# Altium schematic sheet pca10036_sheet3_buttons_and_leds.SchDoc
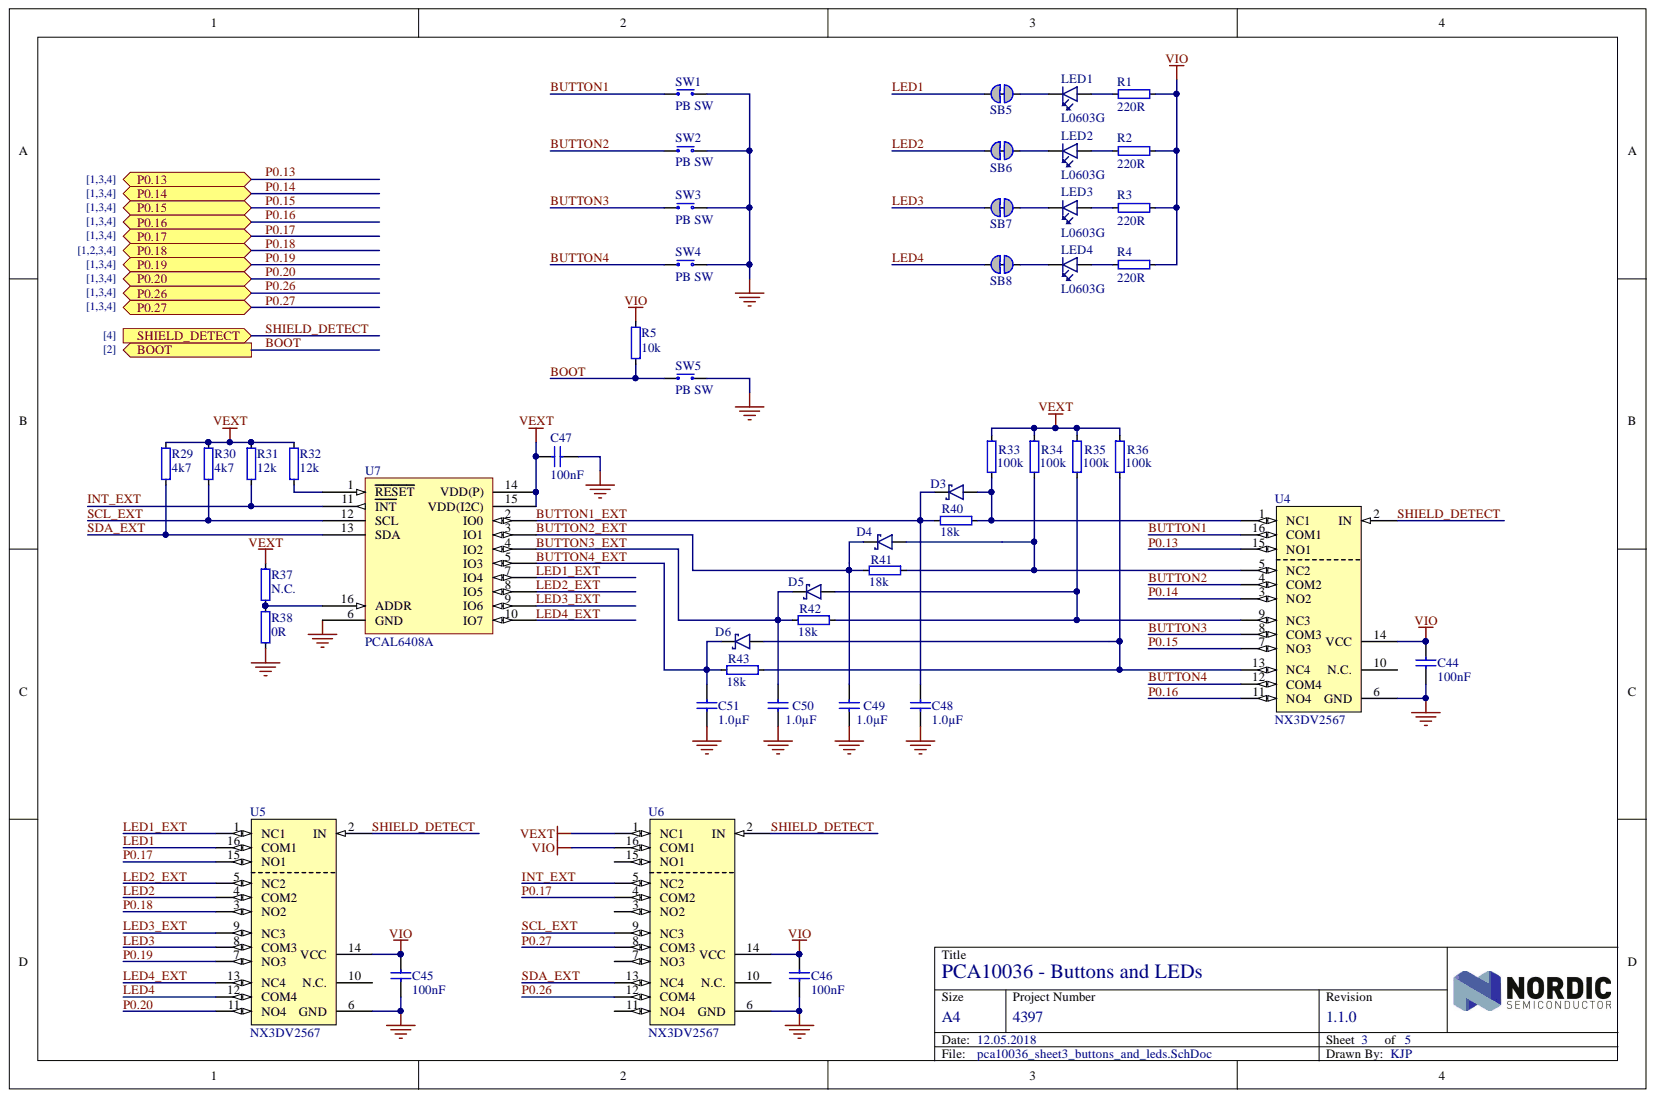
[diagram p0: pca10036_sheet3_buttons_and_leds.SchDoc]

## Parts
C44  100nF  [CAPC1005X04L]
C45  100nF  [CAPC1005X04L]
C46  100nF  [CAPC1005X04L]
C47  100nF  [CAPC1005X04L]
C48  1.0µF  [CAPC1005X04L]
C49  1.0µF  [CAPC1005X04L]
C50  1.0µF  [CAPC1005X04L]
C51  1.0µF  [CAPC1005X04L]
D3  1PS79SB30.115  [SOD-523]  (value not drawn)
D4  1PS79SB30.115  [SOD-523]  (value not drawn)
D5  1PS79SB30.115  [SOD-523]  (value not drawn)
D6  1PS79SB30.115  [SOD-523]  (value not drawn)
LED1  L0603G  [LED_0603_G]
LED2  L0603G  [LED_0603_G]
LED3  L0603G  [LED_0603_G]
LED4  L0603G  [LED_0603_G]
R1  220R  [RESC1005X04L]
R2  220R  [RESC1005X04L]
R3  220R  [RESC1005X04L]
R4  220R  [RESC1005X04L]
R5  10k  [RESC1005X04L]
R29  4k7  [RESC1005X04L]
R30  4k7  [RESC1005X04L]
R31  12k  [RESC1005X04L]
R32  12k  [RESC1005X04L]
R33  100k  [RESC1005X04L]
R34  100k  [RESC1005X04L]
R35  100k  [RESC1005X04L]
R36  100k  [RESC1005X04L]
R37  N.C.  [RESC1005X04L]
R38  0R  [RESC1005X04L]
R40  18k  [RESC1005X04L]
R41  18k  [RESC1005X04L]
R42  18k  [RESC1005X04L]
R43  18k  [RESC1005X04L]
SB5  Solderbridge  [Solderbridge_Shorted]  (value not drawn)
SB6  Solderbridge  [Solderbridge_Shorted]  (value not drawn)
SB7  Solderbridge  [Solderbridge_Shorted]  (value not drawn)
SB8  Solderbridge  [Solderbridge_Shorted]  (value not drawn)
SW1  PB SW  [ALPS_SKHUxxx010_WO_GND]
SW2  PB SW  [ALPS_SKHUxxx010_WO_GND]
SW3  PB SW  [ALPS_SKHUxxx010_WO_GND]
SW4  PB SW  [ALPS_SKHUxxx010_WO_GND]
SW5  PB SW  [ALPS_SKHUxxx010_WO_GND]
U4  NX3DV2567  [XQFN40P180X260X50-16N]
U5  NX3DV2567  [XQFN40P180X260X50-16N]
U6  NX3DV2567  [XQFN40P180X260X50-16N]
U7  PCAL6408A  [XQFN40P180X260X50-16N]

## Ports
BOOT, P0.13, P0.14, P0.15, P0.16, P0.17, P0.18, P0.19, P0.20, P0.26, P0.27, SHIELD_DETECT

## Nets
GND: C44.1 C45.1 C46.1 C47.1 C48.2 C49.1 C50.1 C51.2 R38.1 SW1.2 SW2.2 SW3.2 SW4.2 SW5.2 U4.6(GND) U5.6(GND) U6.6(GND) U7.6(GND)
VEXT: C47.2 R29.2 R30.2 R31.2 R32.2 R33.2 R34.2 R35.2 R36.2 R37.2 U6.1(NC1) U7.14(VDD(P)) U7.15(VDD(I2C))
VIO: C44.2 C45.2 C46.2 R1.2 R2.2 R3.2 R4.2 R5.2 U4.14(VCC) U5.14(VCC) U6.14(VCC) U6.16(COM1)
BOOT: R5.1 SW5.1 ; port BOOT
BUTTON1: SW1.1 U4.16(COM1)
BUTTON1_EXT: C48.1 D3.3 R40.1 U7.2(IO0)
BUTTON2: SW2.1 U4.4(COM2)
BUTTON2_EXT: C49.2 D4.3 R41.1 U7.3(IO1)
BUTTON3: SW3.1 U4.8(COM3)
BUTTON3_EXT: C50.2 D5.3 R42.1 U7.4(IO2)
BUTTON4: SW4.1 U4.12(COM4)
BUTTON4_EXT: C51.1 D6.3 R43.1 U7.5(IO3)
INT_EXT: R31.1 U6.5(NC2) U7.11(INT)
LED1: SB5.1 U5.16(COM1)
LED1_EXT: U5.1(NC1) U7.7(IO4)
LED2: SB6.1 U5.4(COM2)
LED2_EXT: U5.5(NC2) U7.8(IO5)
LED3: SB7.1 U5.8(COM3)
LED3_EXT: U5.9(NC3) U7.9(IO6)
LED4: SB8.1 U5.12(COM4)
LED4_EXT: U5.13(NC4) U7.10(IO7)
P0.13: U4.15(NO1) ; port P0.13
P0.14: U4.3(NO2) ; port P0.14
P0.15: U4.7(NO3) ; port P0.15
P0.16: U4.11(NO4) ; port P0.16
P0.17: U5.15(NO1) U6.4(COM2) ; port P0.17
P0.18: U5.3(NO2) ; port P0.18
P0.19: U5.7(NO3) ; port P0.19
P0.20: U5.11(NO4) ; port P0.20
P0.26: U6.12(COM4) ; port P0.26
P0.27: U6.8(COM3) ; port P0.27
SCL_EXT: R30.1 U6.9(NC3) U7.12(SCL)
SDA_EXT: R29.1 U6.13(NC4) U7.13(SDA)
SHIELD_DETECT: U4.2(IN) U5.2(IN) U6.2(IN) ; port SHIELD_DETECT
NetD3_1: D3.1 R33.1 R40.2 U4.1(NC1)
NetD4_1: D4.1 R34.1 R41.2 U4.5(NC2)
NetD5_1: D5.1 R35.1 R42.2 U4.9(NC3)
NetD6_1: D6.1 R36.1 R43.2 U4.13(NC4)
NetLED1_1: LED1.1 SB5.2
NetLED1_2: LED1.2 R1.1
NetLED2_1: LED2.1 SB6.2
NetLED2_2: LED2.2 R2.1
NetLED3_1: LED3.1 SB7.2
NetLED3_2: LED3.2 R3.1
NetLED4_1: LED4.1 SB8.2
NetLED4_2: LED4.2 R4.1
NetR32_1: R32.1 U7.1(RESET)
NetR37_1: R37.1 R38.2 U7.16(ADDR)

Not connected: U4 10(N.C.); U5 10(N.C.); U6 3(NO2) 7(NO3) 10(N.C.) 11(NO4) 15(NO1)

## Library data of the parts
C44-C47 = C0402-100n: Capacitor, X7R, ±10%; Artikkelnr Noca: 20039200; Artikkelnr Simpro: N.A.; Database Table Name: Capacitors$; Note: 16V; Component Kind: Standard; Footprint Doc: 0402; LatestRevisionDate: 2009-11-23; Library Name: Nordic Passive DB.DbLib; Part Number: C0402-100n; Pin Count: 2; Published: 2009-05-08; Value: 100nF; Publisher: Nordic Semiconductor
C48-C51 = C0402-1µ0: Capacitor, X5R, ±5%; Artikkelnr Noca: 20039235; Artikkelnr Simpro: N.A.; Database Table Name: Capacitors$; Note: 6.3V; Component Kind: Standard; Footprint Doc: 0402; LatestRevisionDate: 2009-11-23; Library Name: Nordic Passive DB.DbLib; Part Number: C0402-1µ0; Pin Count: 2; Published: 2009-05-08; Value: 1.0µF; Publisher: Nordic Semiconductor
D3-D6 = 1PS79SB30.115: Diode Schottky Vf=0.38, Vr=40V, If=200mA, Ir=500nA; Manufacturer: NXP; Artikkelnr Noca: N.A.; Database Table Name: Semiconductors$; Artikkelnr Simpro: N.A.; Component Kind: Standard; Datasheet: http://www.nxp.com/documents/data_sheet/1PS79SB30.pdf; Footprint Doc: SOD-523; LatestRevisionDate: 2014-09-24; Library Name: Nordic Discrete DB.DbLib; Manufacturer Part Number: 1PS79SB30.115; Part Number: 1PS79SB30.115; Pin Count: 2; Published: 2014-09-24; Supplier: Digi-Key; Supplier part number: 568-5973-1-ND; Value: 1PS79SB30.115; Publisher: Nordic Semiconductor
LED1-LED4 = EL19-21SYGC: LED 0603, EL19-21SYGC; Manufacturer: Everlight; Manufacturer Part Number: 19-21SYGC/S530-E1/TR8; Artikkelnr Noca: 13019044; Artikkelnr Simpro: N.A.; Database Table Name: Optoelectronics$; Note: 16 mcd @ 20 mA; Component Kind: Standard; Datasheet: http://www.everlight.com/datasheets/19_21SYGC_S530_E2_TR8.pdf; Footprint Doc: 0603; LatestRevisionDate: 2013-06-04; Library Name: Nordic Discrete DB.DbLib; Part Number: EL19-21SYGC; Pin Count: 2; Published: 2009-05-18; Supplier: Mouser; Supplier part number: 638-1921SYGCS530; Value: L0603G; Publisher: Nordic Semiconductor
R1-R4 = R0402-220: Resistor, ±1%, 0.063W; Artikkelnr Noca: 27049057; Artikkelnr Simpro: N.A.; Database Table Name: Resistors$; Note: Chip Res 1%; Component Kind: Standard; Footprint Doc: 0402; LatestRevisionDate: 2009-11-23; Library Name: Nordic Passive DB.DbLib; Part Number: R0402-220; Pin Count: 2; Published: 2009-05-08; Supplier: Farnell; Supplier part number: 173-8841; Value: 220R; Publisher: Nordic Semiconductor
R5 = R0402-10k: Resistor, ±1%, 0.063W; Artikkelnr Noca: 27049097; Artikkelnr Simpro: N.A.; Database Table Name: Resistors$; Note: Chip Res 1%; Component Kind: Standard; Footprint Doc: 0402; LatestRevisionDate: 2009-11-23; Library Name: Nordic Passive DB.DbLib; Part Number: R0402-10k; Pin Count: 2; Published: 2009-05-08; Supplier: Farnell; Supplier part number: 173-8864; Value: 10k; Publisher: Nordic Semiconductor
R29 R30 = R0402-4k7: Resistor, ±1%, 0.063W; Artikkelnr Noca: 27049089; Artikkelnr Simpro: N.A.; Database Table Name: Resistors$; Note: Chip Res 1%; Component Kind: Standard; Footprint Doc: 0402; LatestRevisionDate: 2009-11-23; Library Name: Nordic Passive DB.DbLib; Part Number: R0402-4k7; Pin Count: 2; Published: 2009-05-08; Supplier: Farnell; Supplier part number: 173-8858; Value: 4k7; Publisher: Nordic Semiconductor
R31 R32 = R0402-12k: Resistor, ±1%, 0.063W; Artikkelnr Noca: 27049099; Artikkelnr Simpro: N.A.; Database Table Name: Resistors$; Note: Chip Res 1%; Component Kind: Standard; Footprint Doc: 0402; LatestRevisionDate: 2009-11-23; Library Name: Nordic Passive DB.DbLib; Part Number: R0402-12k; Pin Count: 2; Published: 2009-05-08; Supplier: Farnell; Supplier part number: 173-8865; Value: 12k; Publisher: Nordic Semiconductor
R33-R36 = R0402-100k: Resistor, ±1%, 0.063W; Artikkelnr Noca: 27049121; Artikkelnr Simpro: N.A.; Database Table Name: Resistors$; Note: Chip Res 1%; Component Kind: Standard; Footprint Doc: 0402; LatestRevisionDate: 2009-11-23; Library Name: Nordic Passive DB.DbLib; Part Number: R0402-100k; Pin Count: 2; Published: 2009-05-08; Supplier: Farnell; Supplier part number: 173-8877; Value: 100k; Publisher: Nordic Semiconductor
R37 = R0402-N.C.: Not mounted; Artikkelnr Noca: N.A.; Database Table Name: Resistors$; Note: Chip Res; Artikkelnr Simpro: N.A.; Component Kind: Standard; Footprint Doc: 0402; LatestRevisionDate: 2009-05-08; Library Name: Nordic Passive DB.DbLib; Part Number: R0402-N.C.; Pin Count: 2; Published: 2009-05-08; Value: N.C.; Publisher: Nordic Semiconductor
R38 = R0402-0R: Resistor, ±5%, 0.063W; Artikkelnr Noca: 27049000; Artikkelnr Simpro: N.A.; Database Table Name: Resistors$; Note: Chip Res 5%; Component Kind: Standard; Footprint Doc: 0402; LatestRevisionDate: 2009-11-23; Library Name: Nordic Passive DB.DbLib; Part Number: R0402-0R; Pin Count: 2; Published: 2009-05-08; Supplier: Farnell; Supplier part number: 146-9661; Value: 0R; Publisher: Nordic Semiconductor
R40-R43 = R0402-18k: Resistor, ±1%, 0.063W; Artikkelnr Noca: 27049103; Artikkelnr Simpro: N.A.; Database Table Name: Resistors$; Note: Chip Res 1%; Component Kind: Standard; Footprint Doc: 0402; LatestRevisionDate: 2009-11-23; Library Name: Nordic Passive DB.DbLib; Part Number: R0402-18k; Pin Count: 2; Published: 2009-05-08; Supplier: Farnell; Supplier part number: 173-8867; Value: 18k; Publisher: Nordic Semiconductor
SB5-SB8 = Solderbridge Shorted: Shorted Solderbridge; Artikkelnr Noca: N.A.; Database Table Name: Connectors$; Artikkelnr Simpro: N.A.; Component Kind: Net Tie; Footprint Doc: Solderbridge_Shorted; LatestRevisionDate: 2012-08-07; Library Name: Nordic Passive DB.DbLib; Part Number: Solderbridge Shorted; Pin Count: 2; Published: 2012-08-07; Value: Solderbridge; Publisher: Nordic Semiconductor
SW1-SW5 = ALPS_SKHUALE010: ALPS - SKHUALE010 - Tactile Switch, SPNO, SMD, 6.5x6.2x2.5mm; 2nd source part number: 688-SKHUALE010; Artikkelnr Noca: 50019001; Artikkelnr Simpro: N.A.; Database Table Name: Rele_Switch$; 2nd source Supplier: Mouser; Component Kind: Standard; Datasheet: https://www1.elfa.se/data1/wwwroot/webroot/Z_DATA/03579000.pdf; Footprint Doc: ALPS_SKHUxxx010_WO_GND; LatestRevisionDate: 2010-02-01; Library Name: Nordic Discrete DB.DbLib; Manufacturer: Alps; Manufacturer Part Number: SKHUALE010; Part Number: ALPS_SKHUALE010; Pin Count: 4; Published: 2010-02-01; Supplier: Elfa; Supplier part number: 35-790-00; Value: PB SW; Publisher: Nordic Semiconductor
U4-U6 = NX3DV2567GU: Low-ohmic four-pole double-throw analog switch; 2nd source part number: 771-NX3DV2567GU115; Manufacturer: NXP Semiconductors; Artikkelnr Noca: N.A.; Database Table Name: Misc IC$; 2nd source Supplier: Mouser; Artikkelnr Simpro: N.A.; Component Kind: Standard; Datasheet: http://www.nxp.com/documents/data_sheet/NX3DV2567.pdf; Footprint Doc: XQFN-16; LatestRevisionDate: 2015-02-17; Library Name: Nordic Active DB.DbLib; Manufacturer Part Number: NX3DV2567GU; Part Number: NX3DV2567GU; Pin Count: 16; Published: 2015-02-17; Supplier: Digi-Key; Supplier part number: 568-5206-1-ND; Value: NX3DV2567; Publisher: Nordic Semiconductor
U7 = PCAL6408AHK: 8-bit I2C-bus I/O expander with interrupt output; 2nd source part number: 771-PCAL6408AHKX; Manufacturer: NXP Semiconductors; Artikkelnr Noca: N.A.; Database Table Name: Misc IC$; 2nd source Supplier: Mouser; Artikkelnr Simpro: N.A.; Component Kind: Standard; Datasheet: http://www.nxp.com/documents/data_sheet/PCAL6408A.pdf; Footprint Doc: XQFN-16; LatestRevisionDate: 2015-04-29; Library Name: Nordic Active DB.DbLib; Manufacturer Part Number: PCAL6408AHK; Part Number: PCAL6408AHK; Pin Count: 16; Published: 2015-04-29; Supplier: Digi-Key; Supplier part number: 568-9944-2-ND; Value: PCAL6408A; Publisher: Nordic Semiconductor
